# Altium schematic sheet tracker_usb_c.SchDoc(tracker_usb_c)
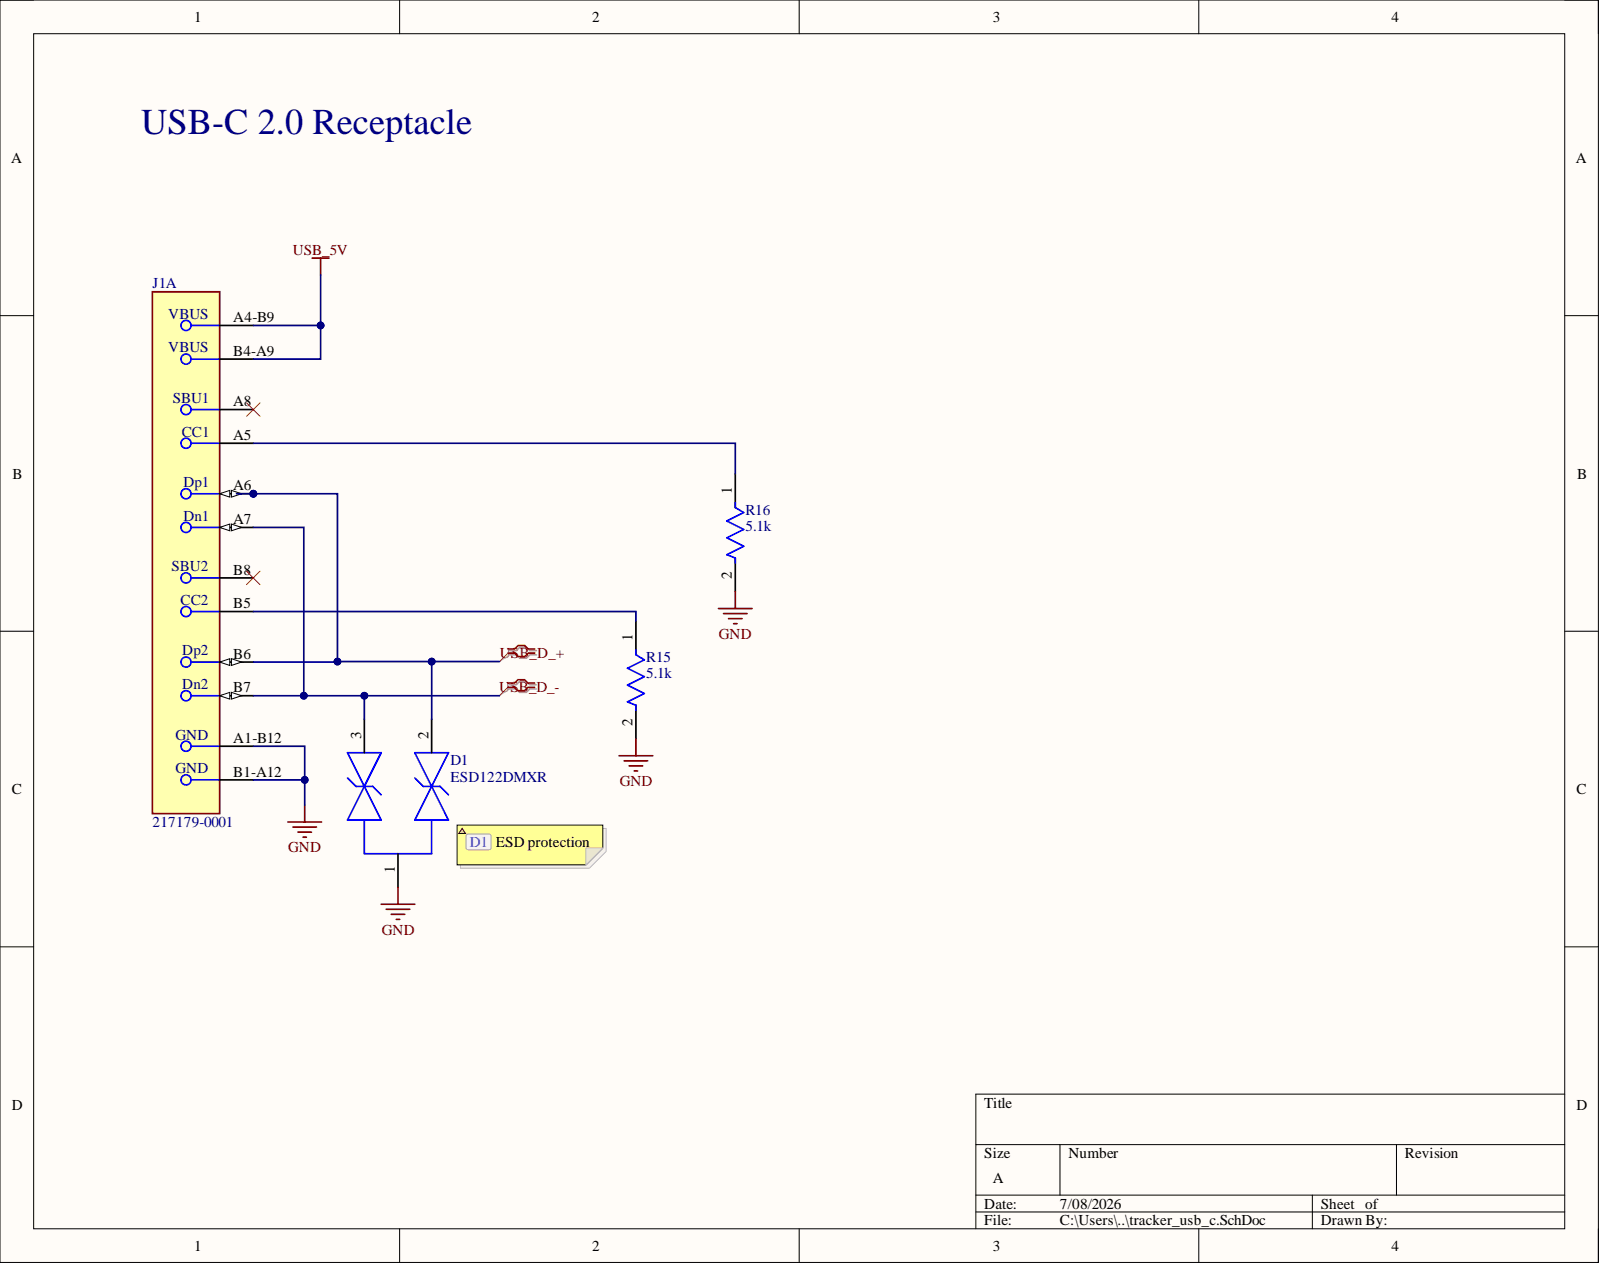
[diagram p0: tracker_usb_c.SchDoc]

## Parts
D1  ESD122DMXR  [FP-DMX0003A-MFG]
J1  217179-0001  [FP-2171790001-MFG]  (part A)
R15  5.1k  [FP-MCT0603-IPC_B]
R16  5.1k  [FP-MCT0603-IPC_B]

## Nets
GND: D1.1 J1.A1-B12 J1.B1-A12 R15.2 R16.2
USB_5V: J1.A4-B9 J1.B4-A9
USB_D_+: D1.2 J1.A6 J1.B6
USB_D_-: D1.3 J1.A7 J1.B7
NetJ1_A5: J1.A5 R16.1
NetJ1_B5: J1.B5 R15.1

Not connected: J1 A8 B8

## Library data of the parts
D1 = CMP-04989-000013-1: TVS DIODE 3.6V 8.4V 3X2SON; Average Rectified Current: 10A; Element Configuration: Common Cathode; Interface: USB; Max Reverse Voltage (DC): 100V; Min Breakdown Voltage: 5.1V; Number of Bidirectional Channels: 2; Peak Pulse Current: 2.5A; Peak Pulse Power: 20W; Power Line Protection: No; Case/Package: TO-220-3; Clamping Voltage: 8.4V; Component Kind: Standard; Library Name: Altium Content Vault; Max Operating Temperature: 125°C; Min Operating Temperature: -40°C; Mount: Through Hole; Number of Channels: 2; Number of Pins: 3; Packaging: Rail/Tube; Resistor Type: Zener; Reverse Standoff Voltage: 3.6V; RoHS Compliant: Yes
J1 = CMP-04767-000028-2: CONN RCP USB2.0 TYP C 24P SMD RA; NexarPartId: 117190526; Current Rating: 1.25A; Gender: Receptacle; Number of Contacts: 16; Voltage Rating: 30V; Component Kind: Standard; Contact Material: Gold, Nickel; Height: 3.36mm; Library Name: Altium Content Vault; Max Operating Temperature: 85°C; Min Operating Temperature: -40°C; USB Standard: USB 2.0
R15 R16 = CMP-02407-010205-1: RES SMD 100 OHM 1% 1/8W 0603; Resistance: 5.1kR; Temperature Coefficient: 25ppm/°C; Tolerance: 0.1%; Case/Package: 0603; Component Kind: Standard; Composition: Thin Film; Contact Plating: Tin; Height: 450um; Lead Free: Lead Free; Length: 1.55mm; Library Name: Altium Content Vault; Max Operating Temperature: 125°C; Min Operating Temperature: -55°C; Radiation Hardening: No; Width: 850um
